# Altium schematic sheet CarSimulator.SchDoc
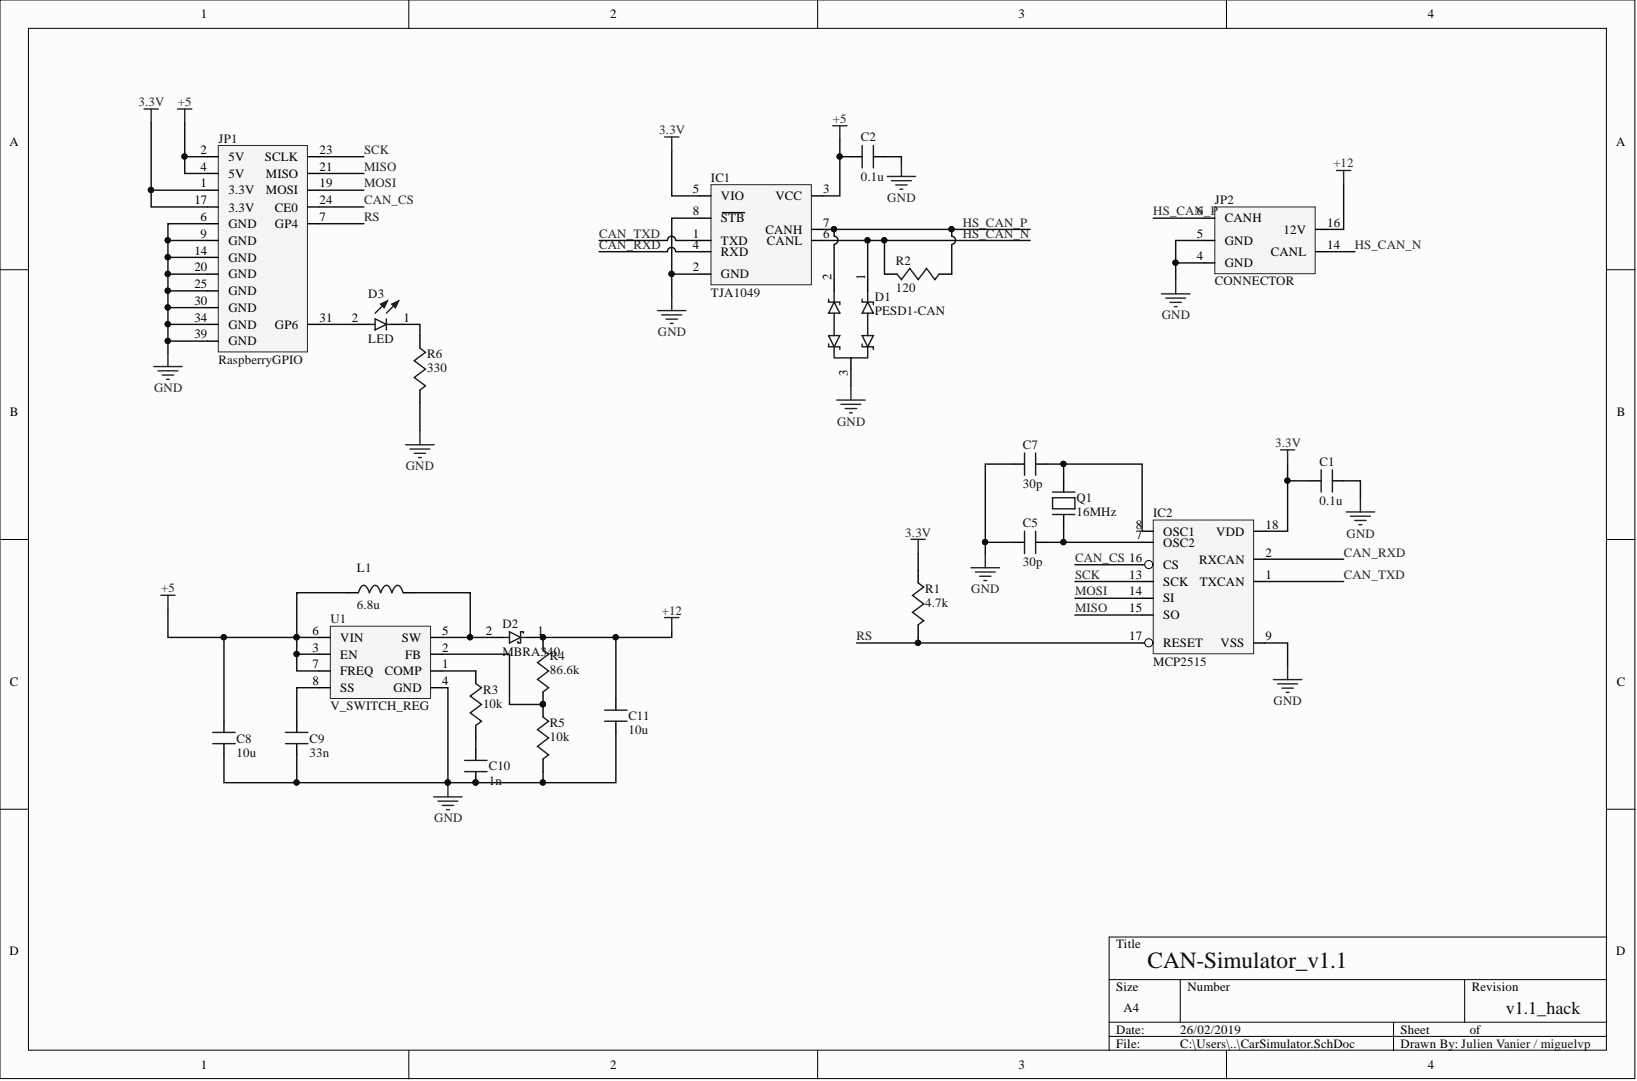
[diagram p0: CarSimulator.SchDoc]

## Parts
C1  0.1u  [Chip0603]
C2  0.1u  [Chip0603]
C5  30p  [Chip0603]
C7  30p  [Chip0603]
C8  10u  [Chip0603]
C9  33n  [Chip0603]
C10  1n  [Chip0603]
C11  10u  [Chip0603]
D1  PESD1-CAN  [SOT323]
D2  MBRA340  [MBRA340]
D3  LED  [LED_Chip0603]
IC1  TJA1049  [SO8]
IC2  MCP2515  [SO18]
JP1  RaspberryGPIO
JP2  CONNECTOR  [Header]
L1  6.8u  [VLS6045]
Q1  16MHz  [ABM3B]
R1  4.7k  [Chip0603]
R2  120  [Chip0603]
R3  10k  [Chip0603]
R4  86.6k  [Chip0805]
R5  10k  [Chip0603]
R6  330  [Chip0603]
U1  V_SWITCH_REG  [MSOP-8]

## Nets
3.3V: C1.2 IC1.5(VIO) IC2.18(VDD) JP1.1(3.3V) JP1.17(3.3V) R1.1
+5: C2.2 C8.1 IC1.3(VCC) JP1.2(5V) JP1.4(5V) L1.2 U1.3(EN) U1.6(VIN) U1.7(FREQ)
+12: C11.1 D2.1 JP2.16(12V) R4.1
GND: C1.1 C2.1 C5.2 C7.2 C8.2 C9.2 C10.1 C11.2 D1.3 IC1.2(GND) IC1.8(STB) IC2.9(VSS) JP1.6(GND) JP1.9(GND) JP1.14(GND) JP1.20(GND) JP1.25(GND) JP1.30(GND) JP1.34(GND) JP1.39(GND) JP2.4(GND) JP2.5(GND) R5.2 R6.2 U1.4(GND)
CAN_CS: IC2.16(CS) JP1.24(CE0)
CAN_RXD: IC1.4(RXD) IC2.2(RXCAN)
CAN_TXD: IC1.1(TXD) IC2.1(TXCAN)
HS_CAN_N: D1.1 IC1.6(CANL) JP2.14(CANL) R2.2
HS_CAN_P: D1.2 IC1.7(CANH) JP2.6(CANH) R2.1
MISO: IC2.15(SO) JP1.21(MISO)
MOSI: IC2.14(SI) JP1.19(MOSI)
RS: IC2.17(RESET) JP1.7(GP4) R1.2
SCK: IC2.13(SCK) JP1.23(SCLK)
NetC5_1: C5.1 IC2.7(OSC2) Q1.1
NetC7_1: C7.1 IC2.8(OSC1) Q1.3
NetC9_1: C9.1 U1.8(SS)
NetC10_2: C10.2 R3.2
NetD2_2: D2.2 L1.1 U1.5(SW)
NetD3_1: D3.1 R6.1
NetD3_2: D3.2 JP1.31(GP6)
NetR3_1: R3.1 U1.1(COMP)
NetR4_2: R4.2 R5.1 U1.2(FB)
N00012:

## Library data of the parts
C1 C2 = Capacitor: Component Kind: Standard; Supplier1: Digikey; Supplier2: Mouser; Value: 0.1u; Library Name: CarSimulator.SchLib
C5 C7 = Capacitor: PartNumber: 06035A300JAT2A; Component Kind: Standard; Supplier1: Digikey; Supplier2: Mouser; SupplierPartNumber 1: 478-7917-1-ND; Value: 30p; Library Name: CarSimulator.SchLib
C8 C11 = Capacitor: PartNumber: CL21A106KOCLRNC; Component Kind: Standard; Supplier1: Digikey; Supplier2: Mouser; SupplierPartNumber 1: 1276-2399-1-ND; Value: 10u; Library Name: CarSimulator.SchLib
C9 = Capacitor: PartNumber: CL10B333KO8NNNC; Component Kind: Standard; Supplier1: Digikey; Supplier2: Mouser; SupplierPartNumber 1: 1276-2044-1-ND; Value: 33n; Library Name: CarSimulator.SchLib
C10 = Capacitor: PartNumber: 885012206083; Component Kind: Standard; Supplier1: Digikey; Supplier2: Mouser; SupplierPartNumber 1: 732-8001-1-ND; SupplierPartNumber2: 710-885012206083; Value: 1n; Library Name: CarSimulator.SchLib
D1 = PESD1-CAN: PartNumber: PESD1CAN-UX; Component Kind: Standard; Supplier1: Digikey; Supplier2: Mouser; SupplierPartNumber 1: 1727-1306-1-ND; SupplierPartNumber 2: 771-PESD1CANUX; Library Name: CarSimulator.SchLib
D2 = MBRA340: PartNumber: MBRA340T3G; Component Kind: Standard; Supplier1: Digikey; Supplier2: Mouser; SupplierPartNumber 1: MBRA340T3G; SupplierPartNumber 2: 863-MBRA340T3G; Library Name: CarSimulator.SchLib
D3 = LED: PartNumber: KPH-1608SGC; Component Kind: Standard; Supplier1: Farnell; SupplierPartNumber 1: 2426215; Library Name: CarSimulator.SchLib
IC1 = TJA1049: PartNumber: TJA1049T,118; Component Kind: Standard; Supplier1: Digikey; Supplier2: Mouser; SupplierPartNumber 1: 568-8682-1-ND; SupplierPartNumber 2: 771-TJA1049T118; Library Name: CarSimulator.SchLib
IC2 = MCP2515: PartNumber: MCP2515-I/SO; Component Kind: Standard; Supplier1: Digikey; Supplier2: Mouser; SupplierPartNumber 1: MCP2515-I/SO-ND; SupplierPartNumber 2: 579-MCP2515-I/SO; Library Name: CarSimulator.SchLib
JP2 = AMP_CONNECTOR: PartNumber: 5103308-1; Component Kind: Standard; Supplier1: Digikey; Supplier2: Mouser; SupplierPartNumber 1: A33159-ND; SupplierPartNumber 2: 571-5103308-1; Library Name: CarSimulator.SchLib
L1 = Inductor: PartNumber: VLS6045AF-6R8M; Component Kind: Standard; Supplier1: Digikey; Supplier2: Mouser; SupplierPartNumber 2: 810-VLS6045AF-6R8M; Value: 6.8u; Library Name: CarSimulator.SchLib
Q1 = XTAL: PartNumber: ABM3B-16.000MHZ-B2-T; Component Kind: Standard; Supplier1: Digikey; Supplier2: Mouser; SupplierPartNumber 1: 535-9122-1-ND; Value: 16MHz; Library Name: CarSimulator.SchLib
R1 = Resistor: PartNumber: CRG0603F4K7; Component Kind: Standard; ComponentLink1Description: Datasheet; Supplier1: Digikey; Supplier2: Mouser; SupplierPartNumber 1: A106050CT-ND; Value: 4.7k; Library Name: CarSimulator.SchLib
R2 = Resistor: PartNumber: CRGCQ0603F120R; Component Kind: Standard; ComponentLink1Description: Datasheet; Supplier1: Digikey; Supplier2: Mouser; SupplierPartNumber 1: A129677CT-ND; Value: 120; Library Name: CarSimulator.SchLib
R3 R5 = Resistor: PartNumber: RR0816P-103-D; Component Kind: Standard; ComponentLink1Description: Datasheet; Supplier1: Digikey; Supplier2: Mouser; SupplierPartNumber 1: RR08P10.0KDCT-ND; Value: 10k; Library Name: CarSimulator.SchLib
R4 = Resistor: PartNumber: ERJ-6ENF8662V; Component Kind: Standard; ComponentLink1Description: Datasheet; Supplier1: Digikey; Supplier2: Mouser; SupplierPartNumber 1: P86.6KCTR-ND; SupplierPartNumber 2: 667-ERJ-6ENF8662V; Value: 86.6k; Library Name: CarSimulator.SchLib
R6 = Resistor: Component Kind: Standard; ComponentLink1Description: Datasheet; Supplier1: Digikey; Supplier2: Mouser; Value: 330; Library Name: CarSimulator.SchLib
U1 = ADP1613: PartNumber: ADP1613ARMZ-R7; Component Kind: Standard; Supplier1: Digikey; Supplier2: Mouser; SupplierPartNumber1: 584-ADP1613ARMZ-R7; SupplierPartNumber 2: ADP1613ARMZ-R7CT-ND; Library Name: CarSimulator.SchLib
JP1 = RaspberryGPIO: Component Kind: Standard; Library Name: CarSimulator.SchLib
